# Altium schematic sheet LEDs, and Motors.SchDoc
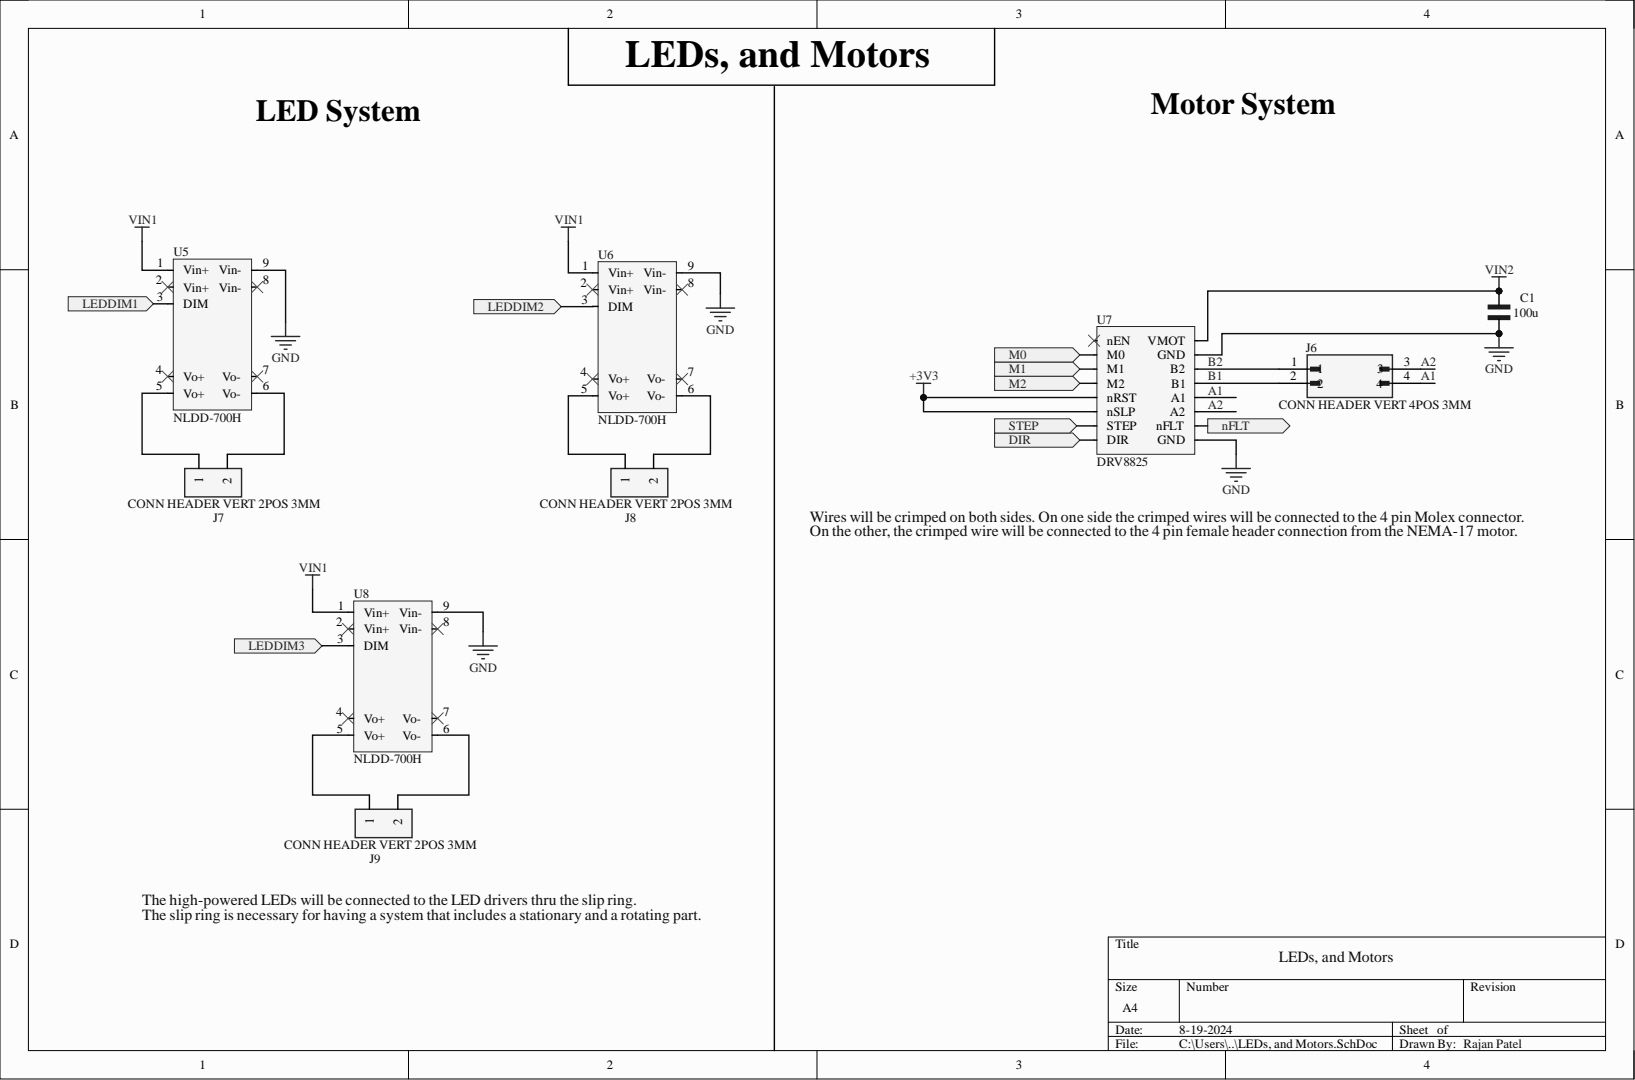
[diagram p0: LEDs, and Motors.SchDoc]

## Parts
C1  100u  [1210 CAP]
J6  CONN HEADER VERT 4POS 3MM  [Connector Molex MicroFit+ 4 Pos R/A]
J7  CONN HEADER VERT 2POS 3MM  [CONN HEADER VERT 2POS 3MM]
J8  CONN HEADER VERT 2POS 3MM  [CONN HEADER VERT 2POS 3MM]
J9  CONN HEADER VERT 2POS 3MM  [CONN HEADER VERT 2POS 3MM]
U5  NLDD-700H  [NLDD-700H]
U6  NLDD-700H  [NLDD-700H]
U7  DRV8825  [DRV8825]
U8  NLDD-700H  [NLDD-700H]

## Ports
DIR, LEDDIM1, LEDDIM2, LEDDIM3, M0, M1, M2, nFLT, STEP

## Nets
+3V3: U7.5(nRST) U7.6(nSLP)
GND: C1.1 U5.9(Vin-) U6.9(Vin-) U7.9(GND) U7.15(GND) U8.9(Vin-)
VIN1: U5.1(Vin+) U6.1(Vin+) U8.1(Vin+)
VIN2: C1.2 U7.16(VMOT)
A1: J6.4 U7.12(A1)
A2: J6.3 U7.11(A2)
B1: J6.2 U7.13(B1)
B2: J6.1 U7.14(B2)
DIR: U7.8(DIR) ; port DIR
LEDDIM1: U5.3(DIM) ; port LEDDIM1
LEDDIM2: U6.3(DIM) ; port LEDDIM2
LEDDIM3: U8.3(DIM) ; port LEDDIM3
M0: U7.2(M0) ; port M0
M1: U7.3(M1) ; port M1
M2: U7.4(M2) ; port M2
nFLT: U7.10(nFLT) ; port nFLT
STEP: U7.7(STEP) ; port STEP
NetJ7_1: J7.1 U5.5(Vo+)
NetJ7_2: J7.2 U5.6(Vo-)
NetJ8_1: J8.1 U6.5(Vo+)
NetJ8_2: J8.2 U6.6(Vo-)
NetJ9_1: J9.1 U8.5(Vo+)
NetJ9_2: J9.2 U8.6(Vo-)

Not connected: U5 2(Vin+) 4(Vo+) 7(Vo-) 8(Vin-); U6 2(Vin+) 4(Vo+) 7(Vo-) 8(Vin-); U7 1(nEN); U8 2(Vin+) 4(Vo+) 7(Vo-) 8(Vin-)

## Library data of the parts
C1 = CAP 0805: Check_prices: https://www.snapeda.com/parts/C2012X8R1H104K125AA/TDK/view-part/?ref=eda; Component Kind: Standard; SnapEDA_Link: https://www.snapeda.com/parts/C2012X8R1H104K125AA/TDK/view-part/?ref=snap; Library Name: Payload schlib.SchLib
J6 = 430450428: Availability: Unavailable; Component Kind: Standard; MP: 430450428; Package: None; Price: None; Library Name: Payload schlib.SchLib
J7-J9 = 0436500228: Rectangular Power Connector, 2 Contact(s), Female, Solder Terminal; Manufacturer: Molex; Manufacturer Part Number: 43650-0228; Manufacturer Series: 43650; Board Mounting Option: PEG; Body Breadth: 0.219inch; Body Depth: 0.39inch; Body Length: 0.38inch; Body/Shell Style: RECEPTACLE; Connector Type: Power,Rectangular; Contact Resistance: 10mR; Contact Style: SQ PIN-SKT; Dielectric Withstanding Voltage (AC): 1.5kV; DIN Conformance: No; Durability: 30Cycles; Insertion Force-Max: 8.0064N; Insulation Resistance: 1GR; Mating Contact Pitch: 0.118inch; Mating Information: MULTIPLE MATING PARTS AVAILABLE; Mounting Option 1: POLARIZED LCK; Mounting Option 2: LOCKING; MP: 0436500200; Number Of Connectors: 1; Number of Contacts: 2; Option: General Purpose; Plating Thickness: 15uin; Polarization Key: POLARIZED HOUSING; Rated Current (Signal): 5A; Reference Standard: UL; Reliability: COMMERCIAL; Withdrawl Force-Min: 3.614N; Availability: Unavailable; Category: Headers and Wire Housings; Component Kind: Standard; ComponentLink1Description: https://datasheet.ciiva.com:443/pdfs/VipMasterIC/IC/MOLE/MOLES07498/MOLES07498-1.pdf?src-supplier=IHS+Markit; ComponentLink1URL: https://datasheet.ciiva.com:443/pdfs/VipMasterIC/IC/MOLE/MOLES07498/MOLES07498-1.pdf?src-supplier=IHS+Markit; ComponentLink2Description: https://datasheet.ciiva.com/13550/0436500228-pcb-headers-148884-13550297.pdf?src-supplier=Mouser; ComponentLink2URL: https://datasheet.ciiva.com/13550/0436500228-pcb-headers-148884-13550297.pdf?src-supplier=Mouser; Contact Finish - Mating: AU; Contact Finish - Termination: TIN/LEAD OVER NICKEL; Contact Gender: Male; Contact Material: Brass; Contact Pattern: RECTANGULAR; Empty Shell: No; Filter Feature: No; Flammability Rating: UL94 V-0; IEC Conformance: No; Insulation Color: Black; Insulation Material: Polymer; Max Operating Temperature: 105°C; MF: Molex; MIL Conformance: No; Min Operating Temperature: -40°C; Mixed Contacts: No; Mounting Style: STRAIGHT; Mounting Technology: Board; Number Of PCB Rows: 1; Number of Rows Loaded: 1; Package: None; PCB Contact Pattern: RECTANGULAR; Price: None; Pricing: 1=0.78, 10=0.537, 50=0.537, 100=0.537, 1000=0.536, 10000=0.473 (USD); Reach SVHC Compliant: Yes; RoHS: Yes; RoHS Compliant: Yes; Shell Material: Polymer; SnapEDA_Link: https://www.snapeda.com/parts/0436500200/Molex/view-part/552556/?ref=snap; Stock: 12783; Supplier: Mouser; Supplier Part Number: 538-43650-0228; Terminal Length: 0.125inch; Terminal Pitch: 2.9972mm; Termination: Solder; Library Name: Payload schlib.SchLib
U5 U6 U8 = NLDD-700H: LED DC/DC Converter 10-56Vdc Input, 700mA Output, Board mount; Manufacturer: Mean Well; Component Kind: Standard; ComponentLink1Description: https://datasheet.octopart.com/NLDD-700H-Mean-Well-datasheet-158237201.pdf?src-supplier=Component+Distributors+Inc.; ComponentLink1URL: https://datasheet.octopart.com/NLDD-700H-Mean-Well-datasheet-158237201.pdf?src-supplier=Component+Distributors+Inc.; Manufacturer Part Number: NLDD-700H; Pricing: 1=5.45 (USD); RoHS: No; Stock: 280; Supplier: Digikey; Supplier Part Number: 1866-NLDD-700H-ND; Library Name: Payload schlib.SchLib
U7 = DRV8825: DRV8825 Stepper Motor Driver Carrier, High Current; Component Kind: Standard; Library Name: Payload schlib.SchLib
